# Altium schematic sheet nrf51x22_qfaa_dcdc.SchDoc(nrf51x22_qfaa_dcdc)
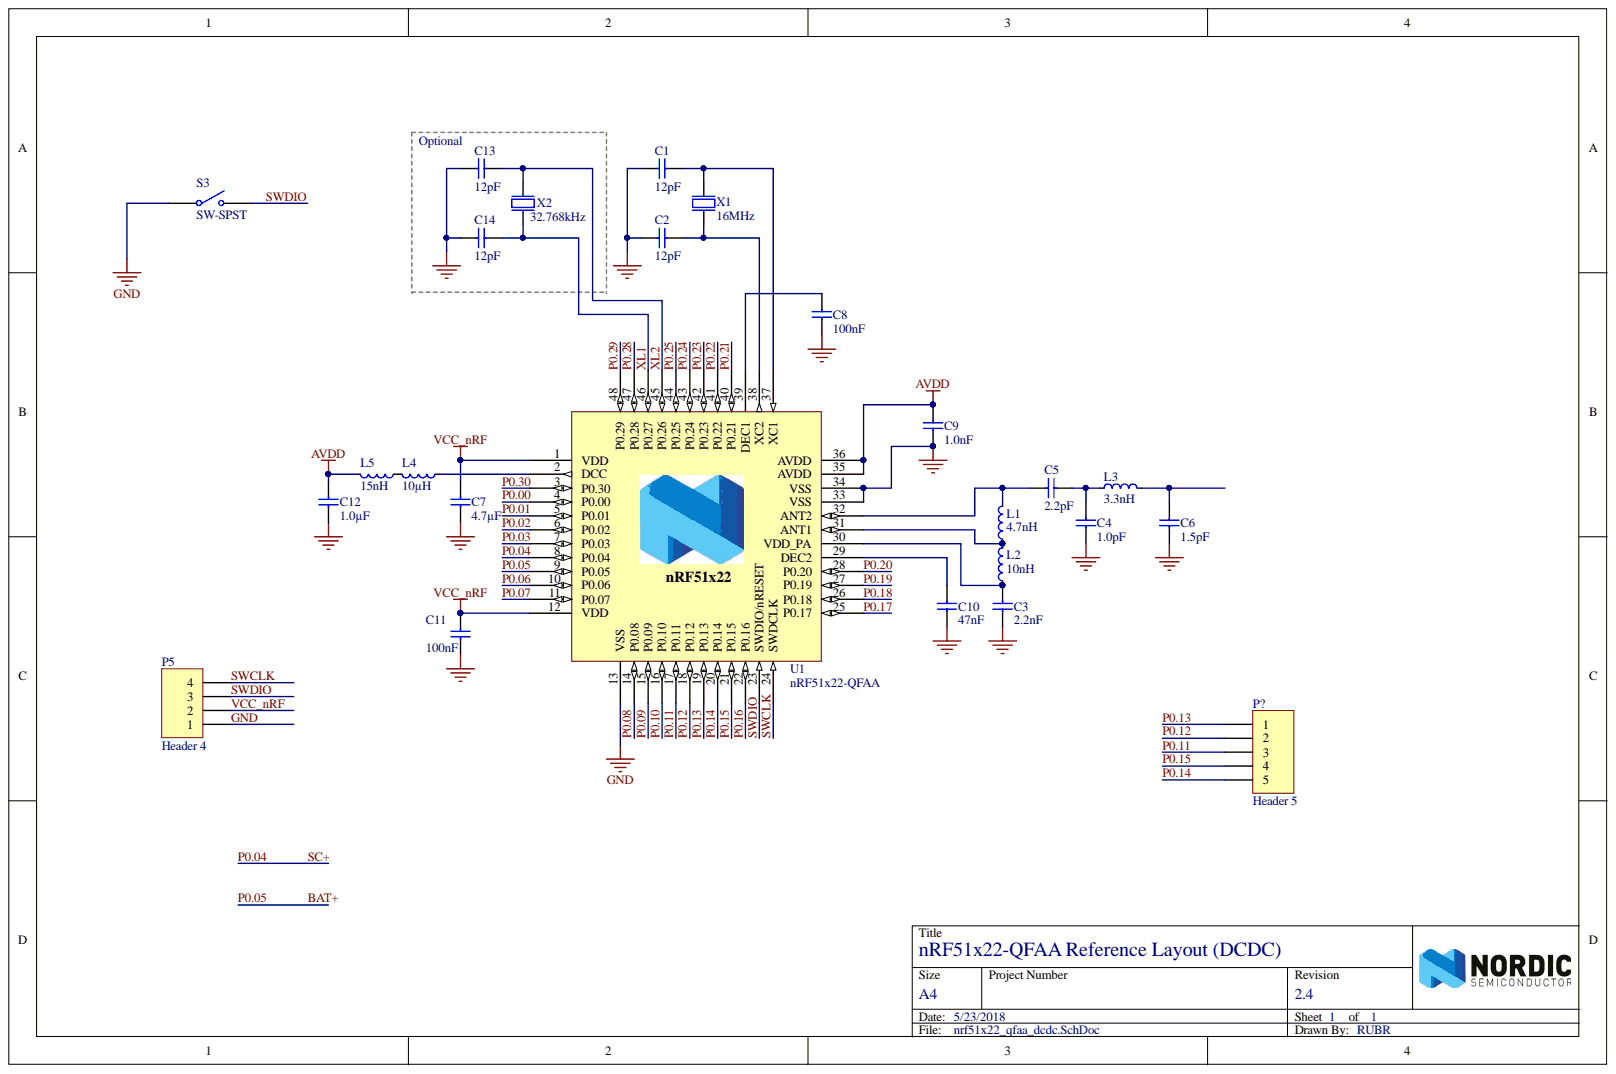
[diagram p0: nrf51x22_qfaa_dcdc.SchDoc]

## Parts
C1  12pF  [CAPC1005X04L]
C2  12pF  [CAPC1005X04L]
C3  2.2nF  [CAPC1005X04L]
C4  1.0pF  [CAPC1005X04L]
C5  2.2pF  [CAPC1005X04L]
C6  1.5pF  [CAPC1005X04L]
C7  4.7µF  [CAPC1608X06L]
C8  100nF  [CAPC1005X04L]
C9  1.0nF  [CAPC1005X04L]
C10  47nF  [CAPC1005X04L]
C11  100nF  [CAPC1005X04L]
C12  1.0µF  [CAPC1608X06L]
C13  12pF  [CAPC1005X04L]
C14  12pF  [CAPC1005X04L]
L1  4.7nH  [INDC1005X04L]
L2  10nH  [INDC1005X04L]
L3  3.3nH  [INDC1005X04L]
L4  10µH  [INDC1608X06L]
L5  15nH  [INDC1005X04L]
P5  Header 4  [HDR1X4]
P?  Header 5  [HDR1X5]
S3  SW-SPST  [SWITCH3*4]
U1  nRF51x22-QFAA  [QFN40P600X600X90-48N]
X1  16MHz  [BT-XTAL_2520]
X2  32.768kHz  [XTAL_3215]

## Nets
AVDD: C9.1 C12.2 L5.2 U1.35(AVDD) U1.36(AVDD)
BAT+: U1.9(P0.05)
GND: C1.2 C2.2 C3.2 C4.2 C6.2 C7.2 C8.2 C9.2 C10.2 C11.2 C12.1 C13.1 C14.1 P5.1 S3.1 U1.13(VSS) U1.33(VSS) U1.34(VSS) U1.49 X1.2 X1.4
P0.00: U1.4(P0.00)
P0.01: U1.5(P0.01)
P0.02: U1.6(P0.02)
P0.03: U1.7(P0.03)
P0.04: U1.8(P0.04)
P0.06: U1.10(P0.06)
P0.07: U1.11(P0.07)
P0.08: U1.14(P0.08)
P0.09: U1.15(P0.09)
P0.10: U1.16(P0.10)
P0.11: P?.3 U1.17(P0.11)
P0.12: P?.2 U1.18(P0.12)
P0.13: P?.1 U1.19(P0.13)
P0.14: P?.5 U1.20(P0.14)
P0.15: P?.4 U1.21(P0.15)
P0.16: U1.22(P0.16)
P0.17: U1.25(P0.17)
P0.18: U1.26(P0.18)
P0.19: U1.27(P0.19)
P0.20: U1.28(P0.20)
P0.21: U1.40(P0.21)
P0.22: U1.41(P0.22)
P0.23: U1.42(P0.23)
P0.24: U1.43(P0.24)
P0.25: U1.44(P0.25)
P0.28: U1.47(P0.28)
P0.29: U1.48(P0.29)
P0.30: U1.3(P0.30)
SWCLK: P5.4 U1.24(SWDCLK)
SWDIO: P5.3 S3.2 U1.23(SWDIO/nRESET)
VCC_nRF: C7.1 C11.1 P5.2 U1.1(VDD) U1.12(VDD)
XL1: C14.2 U1.46(P0.27) X2.2
XL2: C13.2 U1.45(P0.26) X2.1
NetC1_1: C1.1 U1.37(XC1) X1.1
NetC2_1: C2.1 U1.38(XC2) X1.3
NetC3_1: C3.1 L2.1 U1.30(VDD_PA)
NetC4_1: C4.1 C5.2 L3.1
NetC5_1: C5.1 L1.2 U1.32(ANT2)
NetC6_1: C6.1 L3.2
NetC8_1: C8.1 U1.39(DEC1)
NetC10_1: C10.1 U1.29(DEC2)
NetL1_1: L1.1 L2.2 U1.31(ANT1)
NetL4_1: L4.1 U1.2(DCC)
NetL4_2: L4.2 L5.1

## Library data of the parts
C1 C2 C13 C14 = C0402-12p: Capacitor, NP0, ±2%; Note: 50V; Database Table Name: Capacitors$; Artikkelnr Simpro: N.A.; Artikkelnr Noca: 20029159; Value: 12pF; Published: 2009-05-08; Pin Count: 2; Part Number: C0402-12p; Library Name: Nordic Passive DB.DbLib; LatestRevisionDate: 2011-05-11; Footprint Doc: 0402; Component Kind: Standard; Publisher: Nordic Semiconductor
C3 = C0402-2n2: Capacitor, X7R, ±10%; Note: 50V; Database Table Name: Capacitors$; Artikkelnr Simpro: N.A.; Artikkelnr Noca: 20039221; Value: 2.2nF; Published: 2009-05-08; Pin Count: 2; Part Number: C0402-2n2; Library Name: Nordic Passive DB.DbLib; LatestRevisionDate: 2009-11-23; Footprint Doc: 0402; Component Kind: Standard; Publisher: Nordic Semiconductor
C4 = C0402-1.0p: Capacitor, NP0, ±0.1pF; Note: 50V; Database Table Name: Capacitors$; Artikkelnr Simpro: N.A.; Artikkelnr Noca: 20029140; Value: 1.0pF; Published: 2009-05-08; Pin Count: 2; Part Number: C0402-1.0p; Library Name: Nordic Passive DB.DbLib; LatestRevisionDate: 2009-11-23; Footprint Doc: 0402; Component Kind: Standard; Publisher: Nordic Semiconductor
C5 = C0402-2.2p: Capacitor, NP0, ±0.1pF; Note: 50V; Database Table Name: Capacitors$; Artikkelnr Simpro: N.A.; Artikkelnr Noca: 20029145; Value: 2.2pF; Published: 2009-05-08; Pin Count: 2; Part Number: C0402-2.2p; Library Name: Nordic Passive DB.DbLib; LatestRevisionDate: 2009-05-08; Footprint Doc: 0402; Component Kind: Standard; Publisher: Nordic Semiconductor
C6 = C0402-1.5p: Capacitor, NP0, ±0.1pF; Note: 50V; Database Table Name: Capacitors$; Artikkelnr Simpro: N.A.; Artikkelnr Noca: 20029139; Value: 1.5pF; Published: 2009-05-08; Pin Count: 2; Part Number: C0402-1.5p; Library Name: Nordic Passive DB.DbLib; LatestRevisionDate: 2009-11-23; Footprint Doc: 0402; Component Kind: Standard; Publisher: Nordic Semiconductor
C7 = C0603-4µ7: Capacitor, X5R, ±10%; Note: 6.3V; Database Table Name: Capacitors$; Artikkelnr Simpro: N.A.; Artikkelnr Noca: 20069060; Value: 4.7µF; Published: 2009-05-08; Pin Count: 2; Part Number: C0603-4µ7; Library Name: Nordic Passive DB.DbLib; LatestRevisionDate: 2009-11-23; Footprint Doc: 0603; Component Kind: Standard; Publisher: Nordic Semiconductor
C8 C11 = C0402-100n: Capacitor, X7R, ±10%; Note: 16V; Database Table Name: Capacitors$; Artikkelnr Simpro: N.A.; Artikkelnr Noca: 20039200; Value: 100nF; Published: 2009-05-08; Pin Count: 2; Part Number: C0402-100n; Library Name: Nordic Passive DB.DbLib; LatestRevisionDate: 2009-11-23; Footprint Doc: 0402; Component Kind: Standard; Publisher: Nordic Semiconductor
C9 = C0402-1n0: Capacitor, X7R, ±10%; Note: 50V; Database Table Name: Capacitors$; Artikkelnr Simpro: N.A.; Artikkelnr Noca: 20039223; Value: 1.0nF; Published: 2009-05-08; Pin Count: 2; Part Number: C0402-1n0; Library Name: Nordic Passive DB.DbLib; LatestRevisionDate: 2009-11-23; Footprint Doc: 0402; Component Kind: Standard; Publisher: Nordic Semiconductor
C10 = C0402-47n: Capacitor, X7R, ±10%; Note: Chip Cap 10%; Database Table Name: Capacitors$; Artikkelnr Simpro: N.A.; Artikkelnr Noca: 20039389; Value: 47nF; Published: 2009-05-08; Pin Count: 2; Part Number: C0402-47n; Library Name: Nordic Passive DB.DbLib; LatestRevisionDate: 2009-05-08; Footprint Doc: 0402; Component Kind: Standard; Publisher: Nordic Semiconductor
C12 = C0603-1µ0: Capacitor, X7R, ±10%; Note: 16V; Database Table Name: Capacitors$; Artikkelnr Simpro: N.A.; Artikkelnr Noca: 20039335; Value: 1.0µF; Published: 2009-05-08; Pin Count: 2; Part Number: C0603-1µ0; Library Name: Nordic Passive DB.DbLib; LatestRevisionDate: 2013-06-05; Footprint Doc: 0603; Component Kind: Standard; Publisher: Nordic Semiconductor
L1 = L0402-4n7: High frequency chip inductor ±5%; Manufacturer Part Number: LQP15MN4N7B02D; Manufacturer: Murata; Database Table Name: Inductors$; Artikkelnr Simpro: N.A.; Artikkelnr Noca: 33029164; Value: 4.7nH; Supplier part number: 490-1132-1-ND; Supplier: Digi-Key; Published: 2009-05-08; Pin Count: 2; Part Number: L0402-4n7; Note: High frequency chip inductor ±5%; Library Name: Nordic Passive DB.DbLib; LatestRevisionDate: 2012-09-19; Footprint Doc: 0402; Datasheet: http://search.murata.co.jp/Ceramy/image/img/PDF/ENG/L0075S0084LQP15M.pdf; Component Kind: Standard; Publisher: Nordic Semiconductor
L2 = L0402-10n: High frequency chip inductor ±5%; Manufacturer Part Number: LQP15MN10NG02D; Manufacturer: Murata; Database Table Name: Inductors$; Artikkelnr Simpro: N.A.; Artikkelnr Noca: 33029425; Value: 10nH; Supplier part number: 81-LQP15MN10NG02D; Supplier: Mouser; Published: 2010-07-12; Pin Count: 2; Part Number: L0402-10n; Note: High frequency chip inductor ±5%; Library Name: Nordic Passive DB.DbLib; LatestRevisionDate: 2012-09-19; Footprint Doc: 0402; Datasheet: http://search.murata.co.jp/Ceramy/image/img/PDF/ENG/L0075S0084LQP15M.pdf; Component Kind: Standard; Publisher: Nordic Semiconductor
L3 = L0402-3n3: High frequency chip inductor ±5%; Manufacturer Part Number: LQP15MN3N3B02D; Manufacturer: Murata; Database Table Name: Inductors$; Artikkelnr Simpro: N.A.; Artikkelnr Noca: 33029369; Value: 3.3nH; Supplier part number: 490-1130-1-ND; Supplier: Digi-Key; Published: 2009-05-08; Pin Count: 2; Part Number: L0402-3n3; Note: High frequency chip inductor ±5%; Library Name: Nordic Passive DB.DbLib; LatestRevisionDate: 2012-09-19; Footprint Doc: 0402; Datasheet: http://search.murata.co.jp/Ceramy/image/img/PDF/ENG/L0075S0084LQP15M.pdf; Component Kind: Standard; Publisher: Nordic Semiconductor
L4 = L0603-10µ: Chip inductor, IDC,min = 50 mA, ±20%; Manufacturer Part Number: LBMF1608T100K; Manufacturer: Taiyo Yuden; 2nd source part number: 178-6105; Note: LBMF1608T100K 10µH 80mA 0603; Database Table Name: Inductors$; Artikkelnr Simpro: N.A.; Artikkelnr Noca: 33029314; Value: 10µH; Supplier part number: 587-1714-1-ND; Supplier: Digi-Key; Published: 2010-02-24; Pin Count: 2; Part Number: L0603-10µ; Library Name: Nordic Passive DB.DbLib; LatestRevisionDate: 2012-09-19; Footprint Doc: 0603; Datasheet: http://www.yuden.co.jp/ut/product/pdf/elb2_e.pdf; Component Kind: Standard; 2nd source Supplier: Farnell; Publisher: Nordic Semiconductor
L5 = L0402-15n: High frequency chip inductor ±10%; Manufacturer Part Number: HK100515NJ-T; Manufacturer: Taiyo Yuden; 2nd source part number: 658-1328; Database Table Name: Inductors$; Artikkelnr Simpro: N.A.; Artikkelnr Noca: 33029323; Value: 15nH; Supplier part number: 587-1521-1-ND; Supplier: Digi-Key; Published: 2010-07-12; Pin Count: 2; Part Number: L0402-15n; Note: High frequency chip inductor ±10%; Library Name: Nordic Passive DB.DbLib; LatestRevisionDate: 2012-09-19; Footprint Doc: 0402; Datasheet: http://www.yuden.co.jp/productdata/catalog/en/mlci04_e.pdf; Component Kind: Standard; 2nd source Supplier: Farnell; Publisher: Nordic Semiconductor
P5 = Header 4: Header, 4-Pin; Signal Integrity: Connector; Library Name: Miscellaneous Connectors.IntLib; LatestRevisionNote: Re-released for DXP Platform.; LatestRevisionDate: 17-Jul-2002; Component Kind: Standard; Publisher: Altium Limited
P? = Header 5: Header, 5-Pin; Signal Integrity: Connector; Library Name: Miscellaneous Connectors.IntLib; LatestRevisionNote: Re-released for DXP Platform.; LatestRevisionDate: 17-Jul-2002; Component Kind: Standard; Publisher: Altium Limited
S3 = SW-SPST: Single-Pole, Single-Throw Switch; Published: 24-Mar-1999; PackageReference: SPST-2; PackageDescription: Switch; 2 Leads; Library Name: Miscellaneous Devices.IntLib; LatestRevisionNote: Re-released for DXP Platform.; LatestRevisionDate: 17-Jul-2002; Component Kind: Standard; Publisher: Altium Limited
U1 = nRF51x22-QFAA: Multi-protocol Bluetooth Low Energy, ANT and 2.4GHz proprietary system-on-chip; Manufacturer: Nordic Semiconductor; Note: nRF51422 - QFN48 6x6 0.4mm pitch; Database Table Name: nRF Devices$; Artikkelnr Simpro: N.A.; Value: nRF51x22-QFAA; Supplier: Nordic Semiconductor; Published: 2014-09-24; Pin Count: 48; Part Number: nRF51x22-QFAA; Manufacturer Part Number: nRF51x22-QFAA; Library Name: Nordic Active DB.DbLib; LatestRevisionDate: 2014-09-24; Footprint Doc: QFN-48; Component Kind: Standard; Artikkelnr Noca: N.A.; Publisher: Nordic Semiconductor
X1 = NX2520SA-16MHZ-STD-CSW-5: XTAL SMD 2520, 16MHz, 8pF, ±40ppm; Manufacturer: NDK; Note: XTAL SMD 16.000MHz; Database Table Name: Crystal_Osc$; Artikkelnr Simpro: N.A.; Artikkelnr Noca: 22019192; Value: 16MHz; Supplier part number: 644-1169-1-ND; Supplier: Digi-Key; Published: 2012-06-15; Pin Count: 4; Part Number: NX2520SA-16MHZ-STD-CSW-5; Manufacturer Part Number: NX2520SA-16MHZ-STD-CSW-5; Library Name: Nordic Discrete DB.DbLib; LatestRevisionDate: 2012-06-15; Footprint Doc: BT-XTAL_2520; Datasheet: http://www.ndk.com/images/products/catalog/c_NX2520SA-STD-CSW-5_e.pdf; Component Kind: Standard; Publisher: Nordic Semiconductor
X2 = XTAL_FC-135 32.768k: XTAL SMD 3215, 32.768kHz, 9pF, ±20ppm; Manufacturer Part Number: FC-135 32.768KHZ ±20PPM,9.0PF; Manufacturer: Epson Toyocom; 2nd source part number: 127-8037; Note: XTAL SMD 32.768kHz, 3215; Database Table Name: Crystal_Osc$; Artikkelnr Simpro: N.A.; Artikkelnr Noca: 22019091; Value: 32.768kHz; Supplier part number: FC-135 32.7680KA-AC-ND; Supplier: Digi-Key; Published: 2010-10-18; Pin Count: 2; Part Number: XTAL_FC-135 32.768k; Library Name: Nordic Discrete DB.DbLib; LatestRevisionDate: 2014-03-27; Footprint Doc: XTAL_3215; Datasheet: http://www.farnell.com/datasheets/57480.pdf; Component Kind: Standard; 2nd source Supplier: Farnell; Publisher: Nordic Semiconductor
